# Altium schematic sheet Power_Section.SchDoc
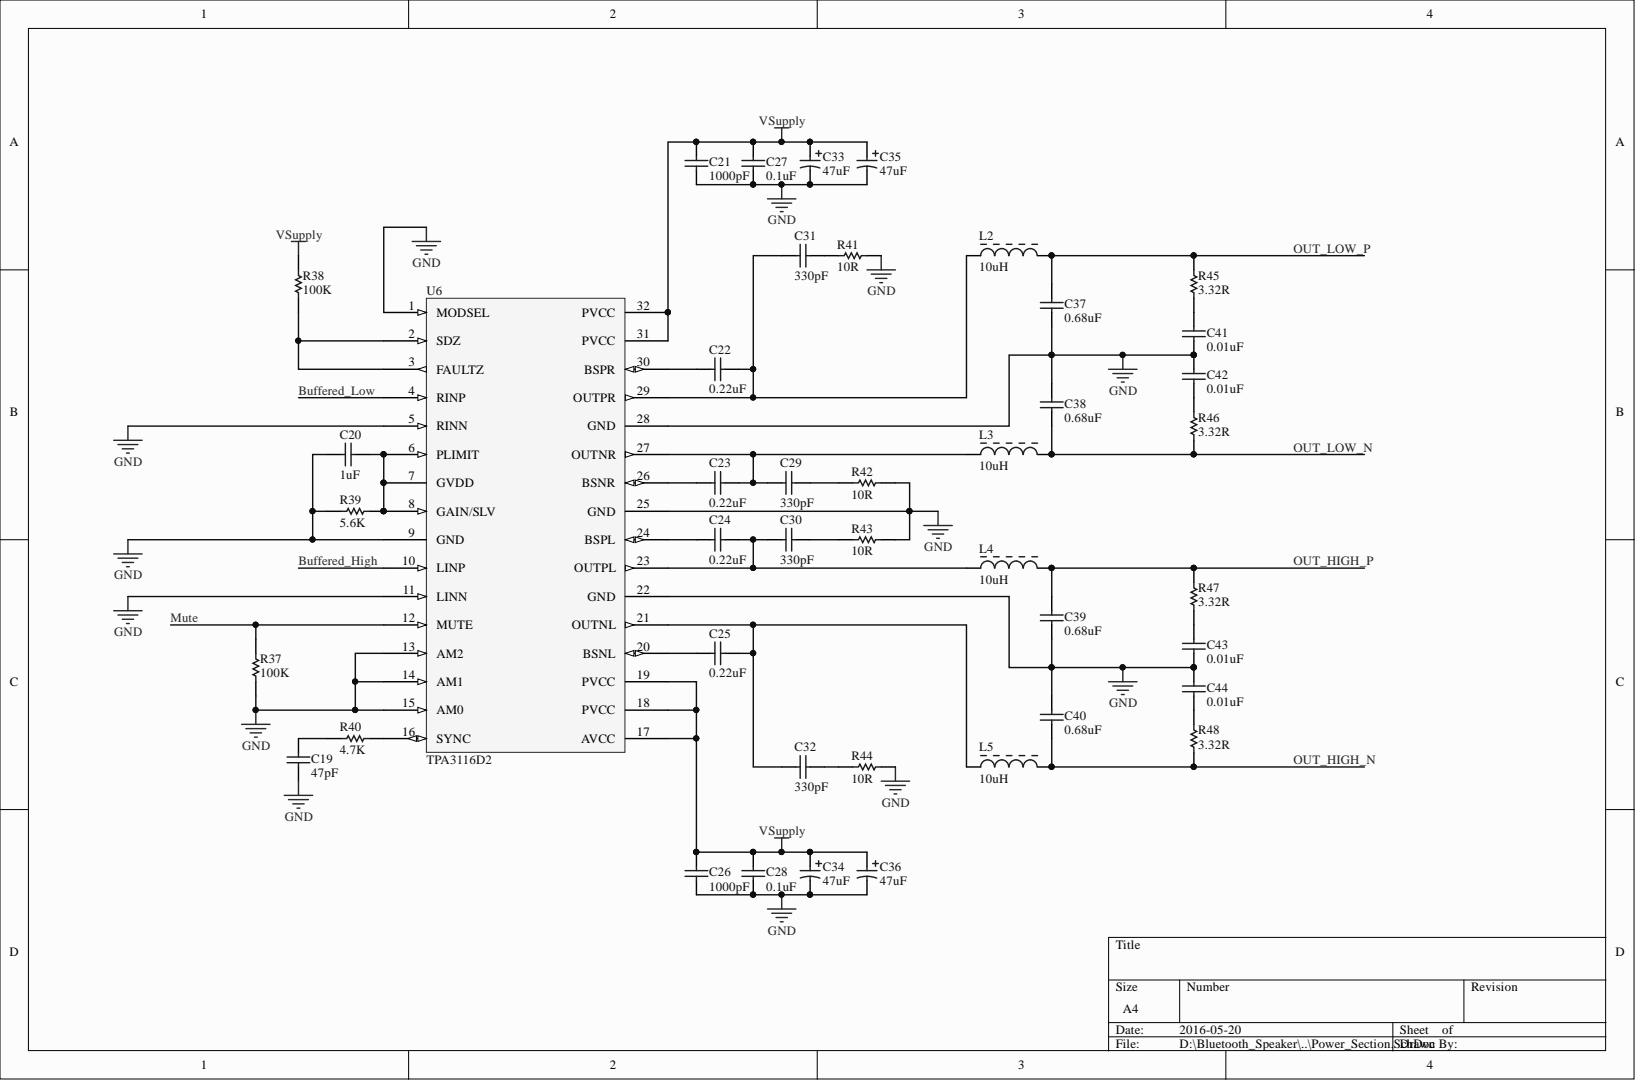
[diagram p0: Power_Section.SchDoc]

## Parts
C19  47pF  [CAPC1608X09N]
C20  1uF  [CAPC1608X09N]
C21  1000pF  [CAPC1608X09N]
C22  0.22uF  [CAPC1608X09N]
C23  0.22uF  [CAPC1608X09N]
C24  0.22uF  [CAPC1608X09N]
C25  0.22uF  [CAPC1608X09N]
C26  1000pF  [CAPC1608X09N]
C27  0.1uF  [CAPC1608X09N]
C28  0.1uF  [CAPC1608X09N]
C29  330pF  [CAPC1608X09N]
C30  330pF  [CAPC1608X09N]
C31  330pF  [CAPC1608X09N]
C32  330pF  [CAPC1608X09N]
C33  47uF  [CAP_EEFK_D]
C34  47uF  [CAP_EEFK_D]
C35  47uF  [CAP_EEFK_D]
C36  47uF  [CAP_EEFK_D]
C37  0.68uF  [CAP Radial 5mm]
C38  0.68uF  [CAP Radial 5mm]
C39  0.68uF  [CAP Radial 5mm]
C40  0.68uF  [CAP Radial 5mm]
C41  0.01uF  [CAPC1608X09N]
C42  0.01uF  [CAPC1608X09N]
C43  0.01uF  [CAPC1608X09N]
C44  0.01uF  [CAPC1608X09N]
L2  10uH  [IND_BOURNS_SRN10]
L3  10uH  [IND_BOURNS_SRN10]
L4  10uH  [IND_BOURNS_SRN10]
L5  10uH  [IND_BOURNS_SRN10]
R37  100K  [0603]
R38  100K  [0603]
R39  5.6K  [0603]
R40  4.7K  [0603]
R41  10R  [0805]
R42  10R  [0805]
R43  10R  [0805]
R44  10R  [0805]
R45  3.32R  [0603]
R46  3.32R  [0603]
R47  3.32R  [0603]
R48  3.32R  [0603]
U6  TPA3116D2  [DAP0032A]

## Nets
GND: C19.1 C20.1 C21.1 C26.1 C27.1 C28.1 C33.2 C34.2 C35.2 C36.2 C37.1 C38.2 C39.1 C40.2 C41.1 C42.2 C43.1 C44.2 R37.1 R39.2 R41.2 R42.2 R43.2 R44.2 U6.1(MODSEL) U6.5(RINN) U6.9(GND) U6.11(LINN) U6.13(AM2) U6.14(AM1) U6.15(AM0) U6.22(GND) U6.25(GND) U6.28(GND) U6.33
VSUPPLY: C21.2 C26.2 C27.2 C28.2 C33.1 C34.1 C35.1 C36.1 R38.2 U6.17(AVCC) U6.18(PVCC) U6.19(PVCC) U6.31(PVCC) U6.32(PVCC)
BUFFERED_HIGH: U6.10(LINP)
BUFFERED_LOW: U6.4(RINP)
MUTE: R37.2 U6.12(MUTE)
OUT_HIGH_N: C40.1 L5.2 R48.1
OUT_HIGH_P: C39.2 L4.2 R47.2
OUT_LOW_N: C38.1 L3.2 R46.1
OUT_LOW_P: C37.2 L2.2 R45.2
NetC19_2: C19.2 R40.1
NetC20_2: C20.2 R39.1 U6.6(PLIMIT) U6.7(GVDD) U6.8(GAIN/SLV)
NetC22_1: C22.1 U6.30(BSPR)
NetC22_2: C22.2 C31.1 L2.1 U6.29(OUTPR)
NetC23_1: C23.1 U6.26(BSNR)
NetC23_2: C23.2 C29.1 L3.1 U6.27(OUTNR)
NetC24_1: C24.1 U6.24(BSPL)
NetC24_2: C24.2 C30.1 L4.1 U6.23(OUTPL)
NetC25_1: C25.1 U6.20(BSNL)
NetC25_2: C25.2 C32.1 L5.1 U6.21(OUTNL)
NetC29_2: C29.2 R42.1
NetC30_2: C30.2 R43.1
NetC31_2: C31.2 R41.1
NetC32_2: C32.2 R44.1
NetC41_2: C41.2 R45.1
NetC42_1: C42.1 R46.2
NetC43_2: C43.2 R47.1
NetC44_1: C44.1 R48.2
NetR38_1: R38.1 U6.2(SDZ) U6.3(FAULTZ)
NetR40_2: R40.2 U6.16(SYNC)

## Library data of the parts
C19 = CAP CER 47pF 0603: Rating: C0G; Voltage: 50V; Component Kind: Standard; Digikey Part Number: 490-1419-1-ND; Value: 47pF; Library Name: Speaker Library.SchLib
C20 = CAP CER 1uF 0603: Voltage: 35V; Component Kind: Standard; Digikey Part Number: 490-10487-1-ND; Value: 1uF; Library Name: Speaker Library.SchLib
C21 C26 = CAP CER 1000pF 0603: Manufacturer: Murata; Rating: C0G; Voltage: 50V; Component Kind: Standard; Digikey Part Number: 490-1451-1-ND; Value: 1000pF; Library Name: Speaker Library.SchLib
C22-C25 = CAP CER 0.22uF 0603: Manufacturer: Murata; Rating: X7R; Voltage: 50V; Component Kind: Standard; Digikey Part Number: 490-5402-1-ND; Value: 0.22uF; Library Name: Speaker Library.SchLib
C27 C28 = CAP CER 0.1uF 0603: Rating: X7R; Tolerance: 10%; Voltage: 100V; Component Kind: Standard; Digikey Part Number: 490-3285-1-ND; Package: 0603; Value: 0.1uF; Library Name: Speaker Library.SchLib
C29-C32 = CAP CER 330pF 0603: Manufacturer: Murata; Rating: C0G; Voltage: 50V; Component Kind: Standard; Digikey Part Number: 490-1439-1-ND; Value: 330pF; Library Name: Speaker Library.SchLib
C33-C36 = CAP ALUM 47uF SMD: Manufacturer: Panasonic; Voltage: 35V; Component Kind: Standard; Digikey Part Number: PCE3842CT-ND; Value: 47uF; Library Name: Speaker Library.SchLib
C37-C40 = CAP 0.68uF Radial 5mm: Output Cap; Manufacturer: EPCOS (TDK); Voltage: 63V; Component Kind: Standard; Digikey Part Number: 495-1114-ND; Value: 0.68uF; Library Name: Speaker Library.SchLib
C41-C44 = CAP CER 0.01uF 0603: Manufacturer: Murata; Rating: C0G; Component Kind: Standard; Digikey Part Number: 490-9666-1-ND; Value: 0.01uF; Library Name: Speaker Library.SchLib
L2-L5 = IND 10uH 5A SMD: Component Kind: Standard; Digikey Part Number: SRN1060-100MCT-ND; Value: 10uH; Library Name: Speaker Library.SchLib
R37 R38 = RES 100K 0603: Power: 0.1W; Tolerance: 1%; Component Kind: Standard; Value: 100K; Library Name: Speaker Library.SchLib
R39 = RES 5.6K 0603: Tolerance: 1%; Component Kind: Standard; Type: RES; Value: 5.6K; Library Name: Speaker Library.SchLib
R40 = RES 4.7K 0603: Tolerance: 1%; Component Kind: Standard; Digikey Part Number: 311-4.70KHRCT-ND; Type: RES; Value: 4.7K; Library Name: Speaker Library.SchLib
R41-R44 = RES 10R 0805: Power: 0.25W; Tolerance: 1%; Component Kind: Standard; Digikey Part Number: RNCP0805FTD10R0CT-ND; Type: RES; Value: 10R; Library Name: Speaker Library.SchLib
R45-R48 = RES 3.32R 0603: Power: 0.1W; Tolerance: 1%; Component Kind: Standard; Digikey Part Number: 311-3.32HRCT-ND; Type: RES; Value: 3.32R; Library Name: Speaker Library.SchLib
U6 = TPA3116D2: Component Kind: Standard; Digikey Part Number: 296-39337-1-ND; Library Name: Speaker Library.SchLib
